# Altium schematic sheet Sensor_ascii.SchDoc(Sensor_ascii)
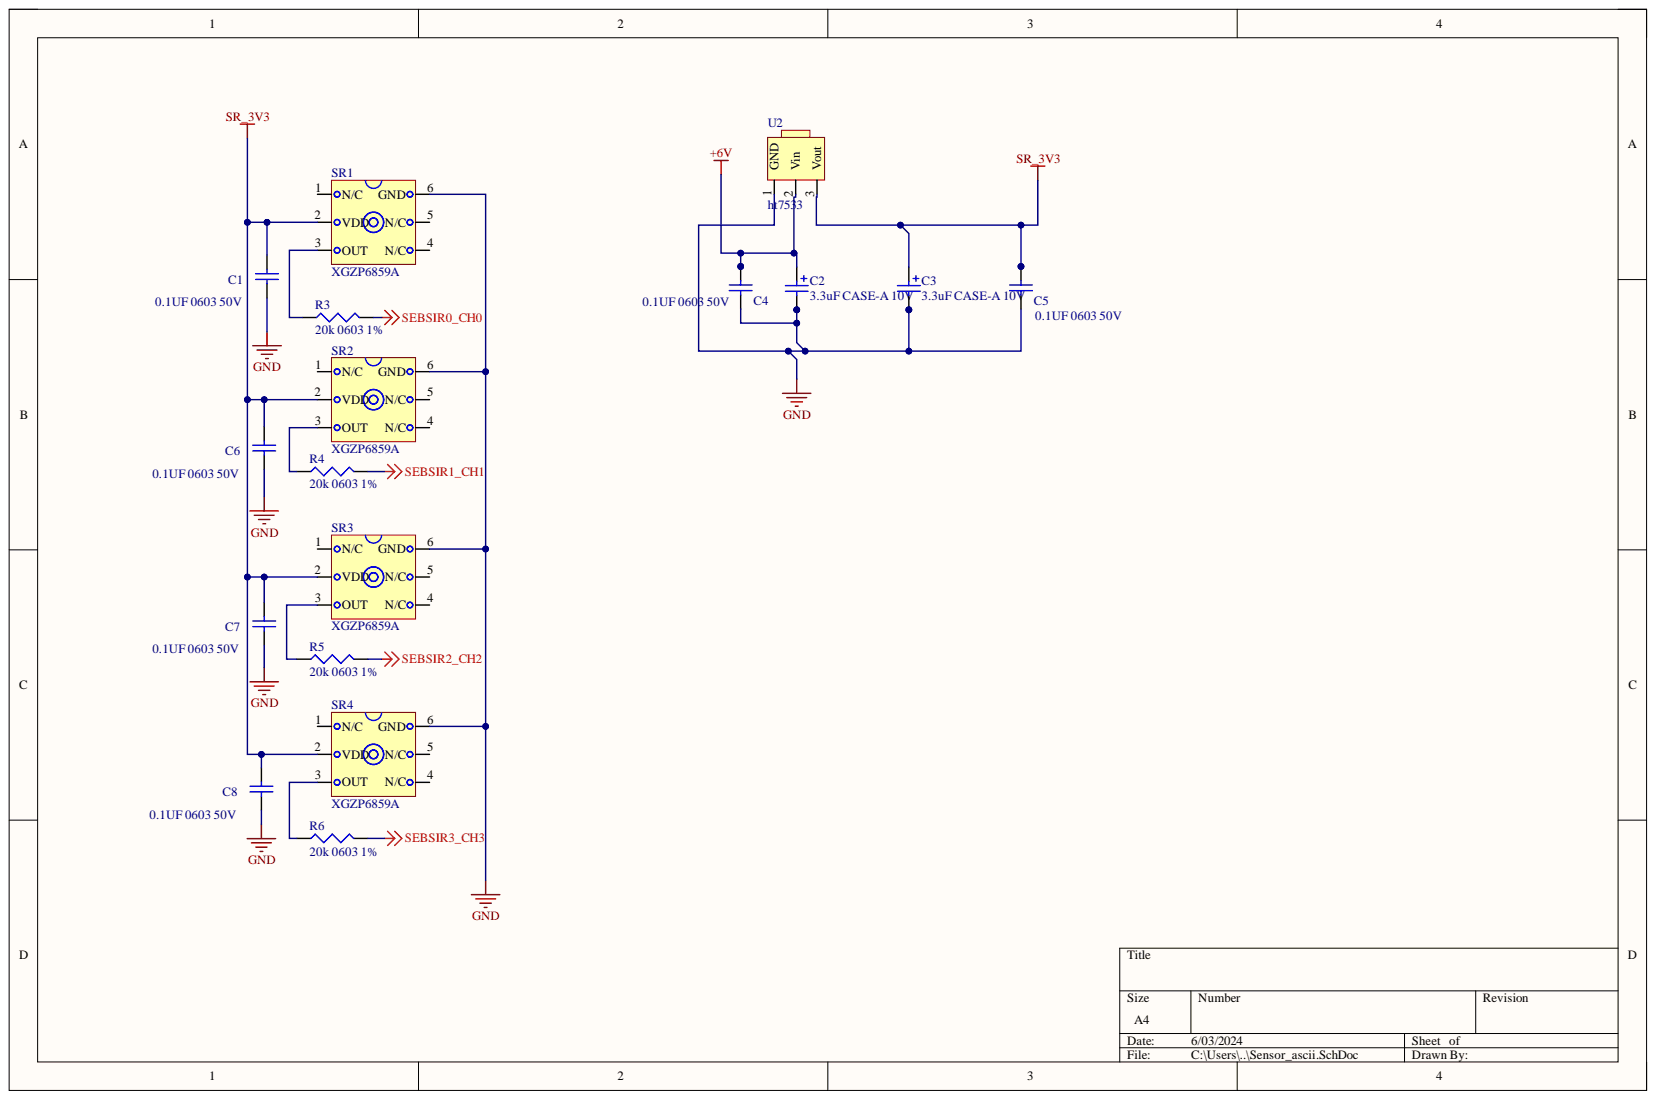
[diagram p0: Sensor_ascii.SchDoc]

## Parts
C1  0.1UF 0603 50V  耐压: 50V  [CAP0603_3D]
C2  3.3uF CASE-A 10V  [TAN_CAP_CASE-A]
C3  3.3uF CASE-A 10V  [TAN_CAP_CASE-A]
C4  0.1UF 0603 50V  封装: 0603  耐压: 50V  [CAP0603_3D]
C5  0.1UF 0603 50V  [CAP0603_3D]
C6  0.1UF 0603 50V  耐压: 50V  [CAP0603_3D]
C7  0.1UF 0603 50V  耐压: 50V  [CAP0603_3D]
C8  0.1UF 0603 50V  耐压: 50V  [CAP0603_3D]
R3  20k 0603 1%  精度误差: 1%  [RES0603_3D]
R4  20k 0603 1%  精度误差: 1%  [RES0603_3D]
R5  20k 0603 1%  精度误差: 1%  [RES0603_3D]
R6  20k 0603 1%  精度误差: 1%  [RES0603_3D]
SR1  XGZP6859A  [XGZP6859A]
SR2  XGZP6859A  [XGZP6859A]
SR3  XGZP6859A  [XGZP6859A]
SR4  XGZP6859A  [XGZP6859A]
U2  ht7533  [SOT89-3]

## Nets
+6V: C2.1 C4.2 U2.2
GND: C1.1 C2.2 C3.2 C4.1 C5.1 C6.1 C7.1 C8.1 SR1.6(GND) SR2.6(GND) SR3.6(GND) SR4.6(GND) U2.1
SEBSIR0_CH0: R3.2
SEBSIR1_CH1: R4.2
SEBSIR2_CH2: R5.2
SEBSIR3_CH3: R6.2
SR_3V3: C1.2 C3.1 C5.2 C6.2 C7.2 C8.2 SR1.2(VDD) SR2.2(VDD) SR3.2(VDD) SR4.2(VDD) U2.3
NetR3_1: R3.1 SR1.3(OUT)
NetR4_1: R4.1 SR2.3(OUT)
NetR5_1: R5.1 SR3.3(OUT)
NetR6_1: R6.1 SR4.3(OUT)

Not connected: SR1 1(N/C) 4(N/C) 5(N/C); SR2 1(N/C) 4(N/C) 5(N/C); SR3 1(N/C) 4(N/C) 5(N/C); SR4 1(N/C) 4(N/C) 5(N/C)

## Library data of the parts
C1 C5-C8 = CAP_SMT: 贴片多层陶瓷电容; 容值误差: 10%; 封装: 0603; Component Kind: Standard; Library Name: hwt.SchLib
  C5: 耐压: 50V
C2 C3 = CAP_TAN_SMT: Component Kind: Standard; Library Name: hwt.SchLib
C4 = CAP_SMT: 贴片多层陶瓷电容; 容值误差: 10%; Component Kind: Standard; Library Name: hwt.SchLib
R3-R6 = RES_SMT: Component Kind: Standard; Library Name: hwt.SchLib
SR1-SR4 = XGZP6859A: Component Kind: Standard; Library Name: hwt.SchLib
U2 = ht7533: Component Kind: Standard; Library Name: hhh.SCHLIB
